# Altium schematic sheet DAC.SchDoc
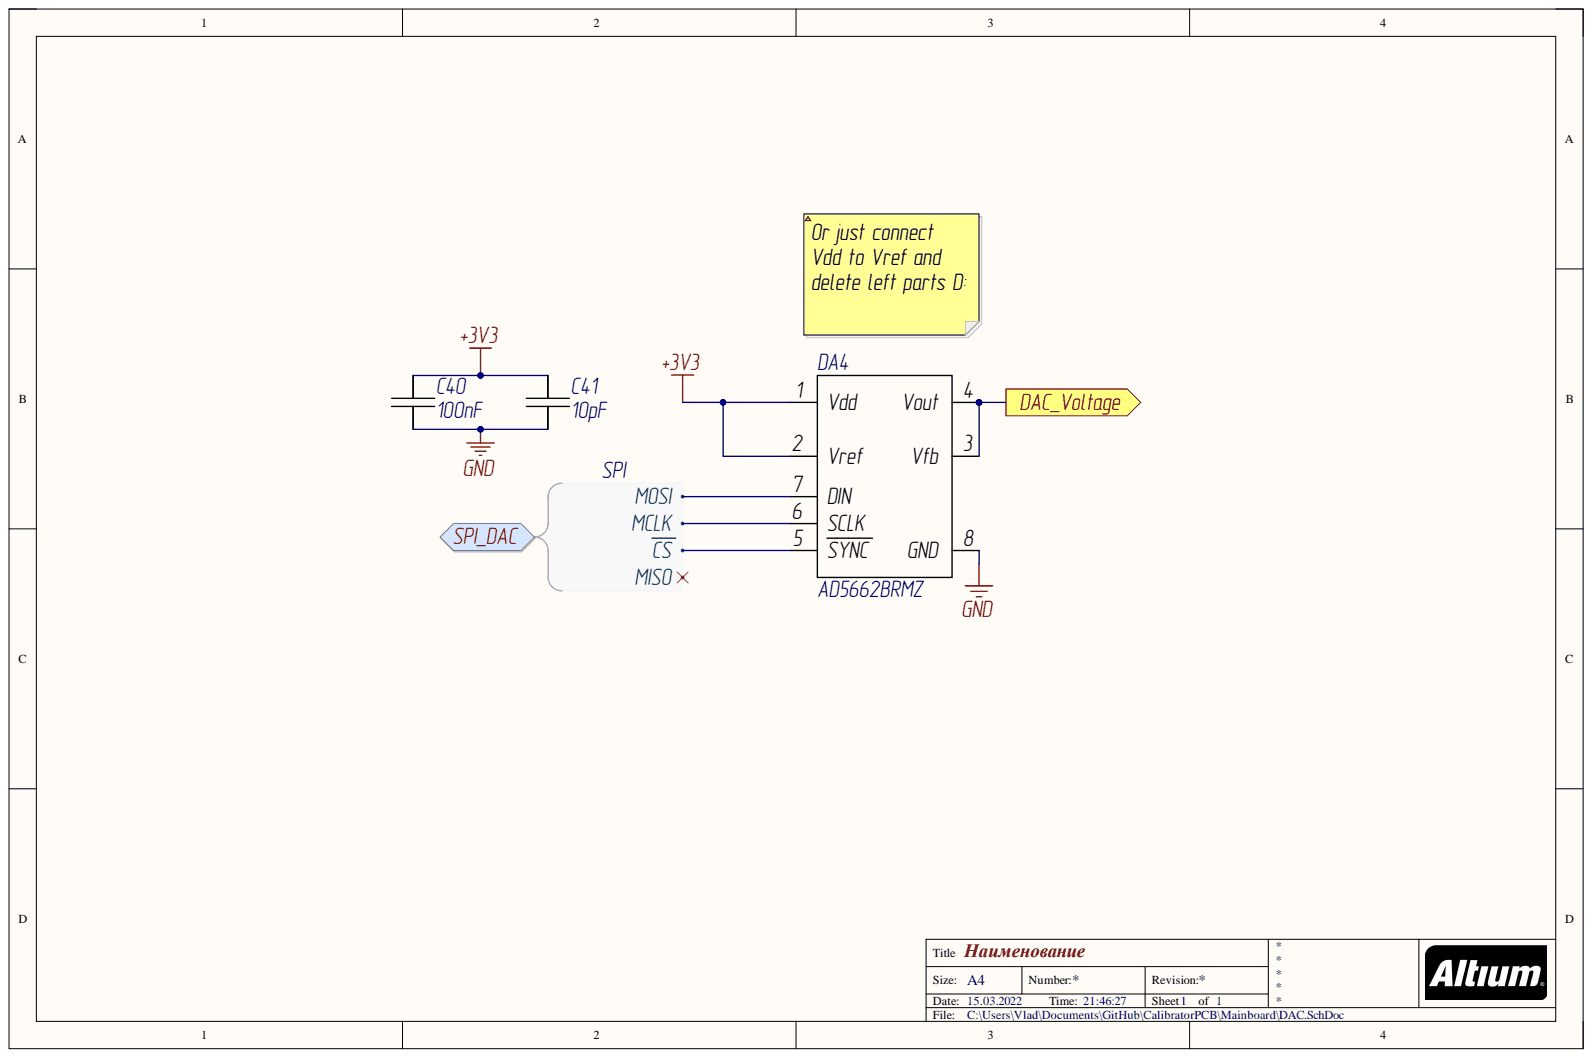
[diagram p0: DAC.SchDoc]

## Parts
C40  value 100nF  [CAP0402]  (hidden comment: 100nF 50V)
C41  value 10pF  [CAP0402]  (hidden comment: 10pF 50V)
DA4  AD5662BRMZ  [TSOP65P490X110-8N]

## Ports
DAC_Voltage, SPI_DAC, SPI_DAC.MCLK, SPI_DAC.MISO, SPI_DAC.MOSI, SPI_DAC~{.CS}

## Nets
+3V3: C40.2 C41.2 DA4.1(Vdd) DA4.2(Vref)
GND: C40.1 C41.1 DA4.8(GND)
DAC_Voltage: DA4.3(Vfb) DA4.4(Vout) ; port DAC_Voltage
NetDA4_5: DA4.5(SYNC) ; port SPI_DAC
NetDA4_6: DA4.6(SCLK) ; port SPI_DAC
NetDA4_7: DA4.7(DIN) ; port SPI_DAC
N00001: ; port SPI_DAC

## Library data of the parts
C40 = CAP0402: Manufacturer: Samsung Electro-Mechanics; ManufacturerAlt: JLCPCB; MPN: CL05B104KB54PNC; MPNAlt: C307331; BOMDescription: SMD CHIP CERAMIC CAPACITOR CAP0402 100nF 10% 50V  CL05B104KB54PNC; Database Table Name: Symbol_SchLib; MntType: SMD; Notes: Basic Part; Tolerance: 10%; CFootprint: CAP0402; ClassName: Capacitor; Component Kind: Standard; ComponentLink1Description: Datasheet; ComponentLink1URL: https://datasheet.lcsc.com/lcsc/1810191222_Samsung-Electro-Mechanics-CL05B104KB54PNC_C307331.pdf; Height: 0.5mm; Library Name: Library.DbLib; MSL: MSL 1; Package: CAP0402; Part Number: CAP0402; PartType: CHIP CERAMIC CAPACITOR; Pins: 2; RoHS: Comply; Voltage: 50V; Weight: 1.500mg
C41 = CAP0402: Manufacturer: Samsung Electro-Mechanics; ManufacturerAlt: JLCPCB; MPN: CL05C100JB5NNNC; MPNAlt: C32949; BOMDescription: SMD CHIP CERAMIC CAPACITOR CAP0402 10pF  50V  CL05C100JB5NNNC; Database Table Name: Symbol_SchLib; MntType: SMD; CFootprint: CAP0402; ClassName: Capacitor; Component Kind: Standard; ComponentLink1Description: Datasheet; Library Name: Library.DbLib; MSL: MSL 1; Package: CAP0402; Part Number: CAP0402; PartType: CHIP CERAMIC CAPACITOR; Pins: 2; RoHS: Comply; Voltage: 50V
DA4 = AD5662: Manufacturer: Analog Devices; BOMDescription: SMD DAC MSOP-8 AD5662    AD5662BRMZ; Database Table Name: Symbol_SchLib; MntType: SMD; Value: AD5662; CFootprint: TSOP65P490X110-8N; ClassName: DAC; Component Kind: Standard; ComponentLink1Description: Datasheet; ComponentLink1URL: https://www.analog.com/media/en/technical-documentation/data-sheets/AD5662.pdf; Library Name: Library.DbLib; MPN: AD5662BRMZ; MSL: MSL 1; Package: MSOP-8; Part Number: AD5662; PartType: DAC; Pins: 8; RoHS: Comply
